# Altium schematic sheet make_etf_great_again.SchDoc
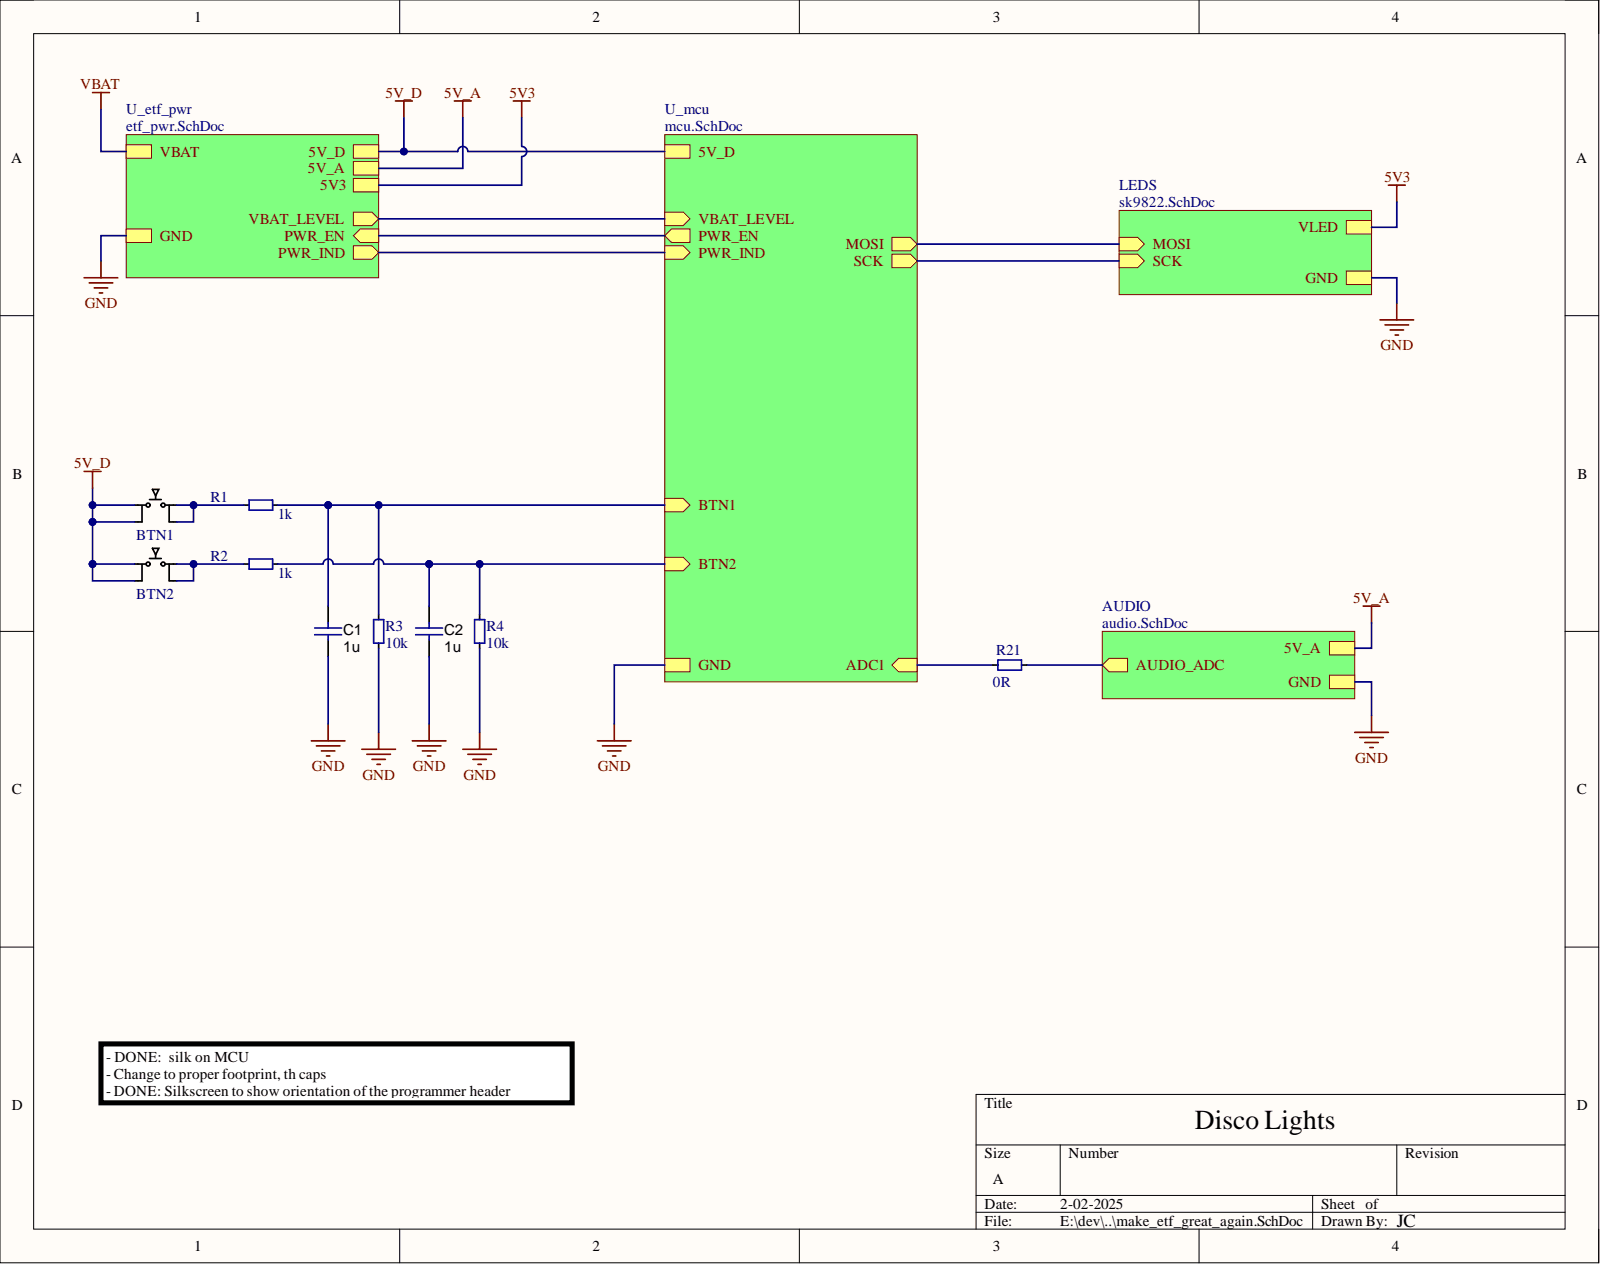
[diagram p0: make_etf_great_again.SchDoc]

## Parts
BTN1  Tactile Button  [SW_TS02-66-150-BK-160-LCR-D]  (value not drawn)
BTN2  Tactile Button  [SW_TS02-66-150-BK-160-LCR-D]  (value not drawn)
C1  value 1u  [1206_180]  (hidden comment: CAP, 1206)
C2  value 1u  [1206_180]  (hidden comment: CAP, 1206)
R1  value 1k  [TH]  (hidden comment: TH, resistor)
R2  value 1k  [TH]  (hidden comment: TH, resistor)
R3  value 10k  [TH]  (hidden comment: TH, resistor)
R4  value 10k  [TH]  (hidden comment: TH, resistor)
R21  value 0R  [0603]  (hidden comment: 0603, resistor)

## Nets
5V_D: BTN1.3 BTN1.4 BTN2.3 BTN2.4
GND: C1.2 C2.2 R3.1 R4.1
NetBTN1_1: BTN1.1 BTN1.2 R1.1
NetBTN2_1: BTN2.1 BTN2.2 R2.1
NetC1_1: C1.1 R1.2 R3.2
NetC2_1: C2.1 R2.2 R4.2
NetR21_0: R21.0
NetR21_1: R21.1
5V3:
5V_A:
MOSI:
PWR_EN:
PWR_IND:
SCK:
VBAT:
VBAT_LEVEL:

## Library data of the parts
BTN1 BTN2 = TacButton TH: Tactile Switch Small Low; Mount: No; Component Kind: Standard; Value: Button; Library Name: urad.IntLib
C1 C2 = CAP, 1206: Manufacturer Part Number: CC1206JKX7R7BB105; Component Kind: Standard; JLCPCB Part #: C1848; Mount: Yes; Library Name: urad.IntLib
R1-R4 = TH, resistor: Mount: No; Component Kind: Standard; Library Name: jclib.IntLib
R21 = 0603, resistor: Manufacturer Part Number: RC0603JR-070RL; Component Kind: Standard; JLCPCB Part #: C95177; Mount: Yes; Library Name: jclib.IntLib
